# Altium schematic sheet MCU.SchDoc
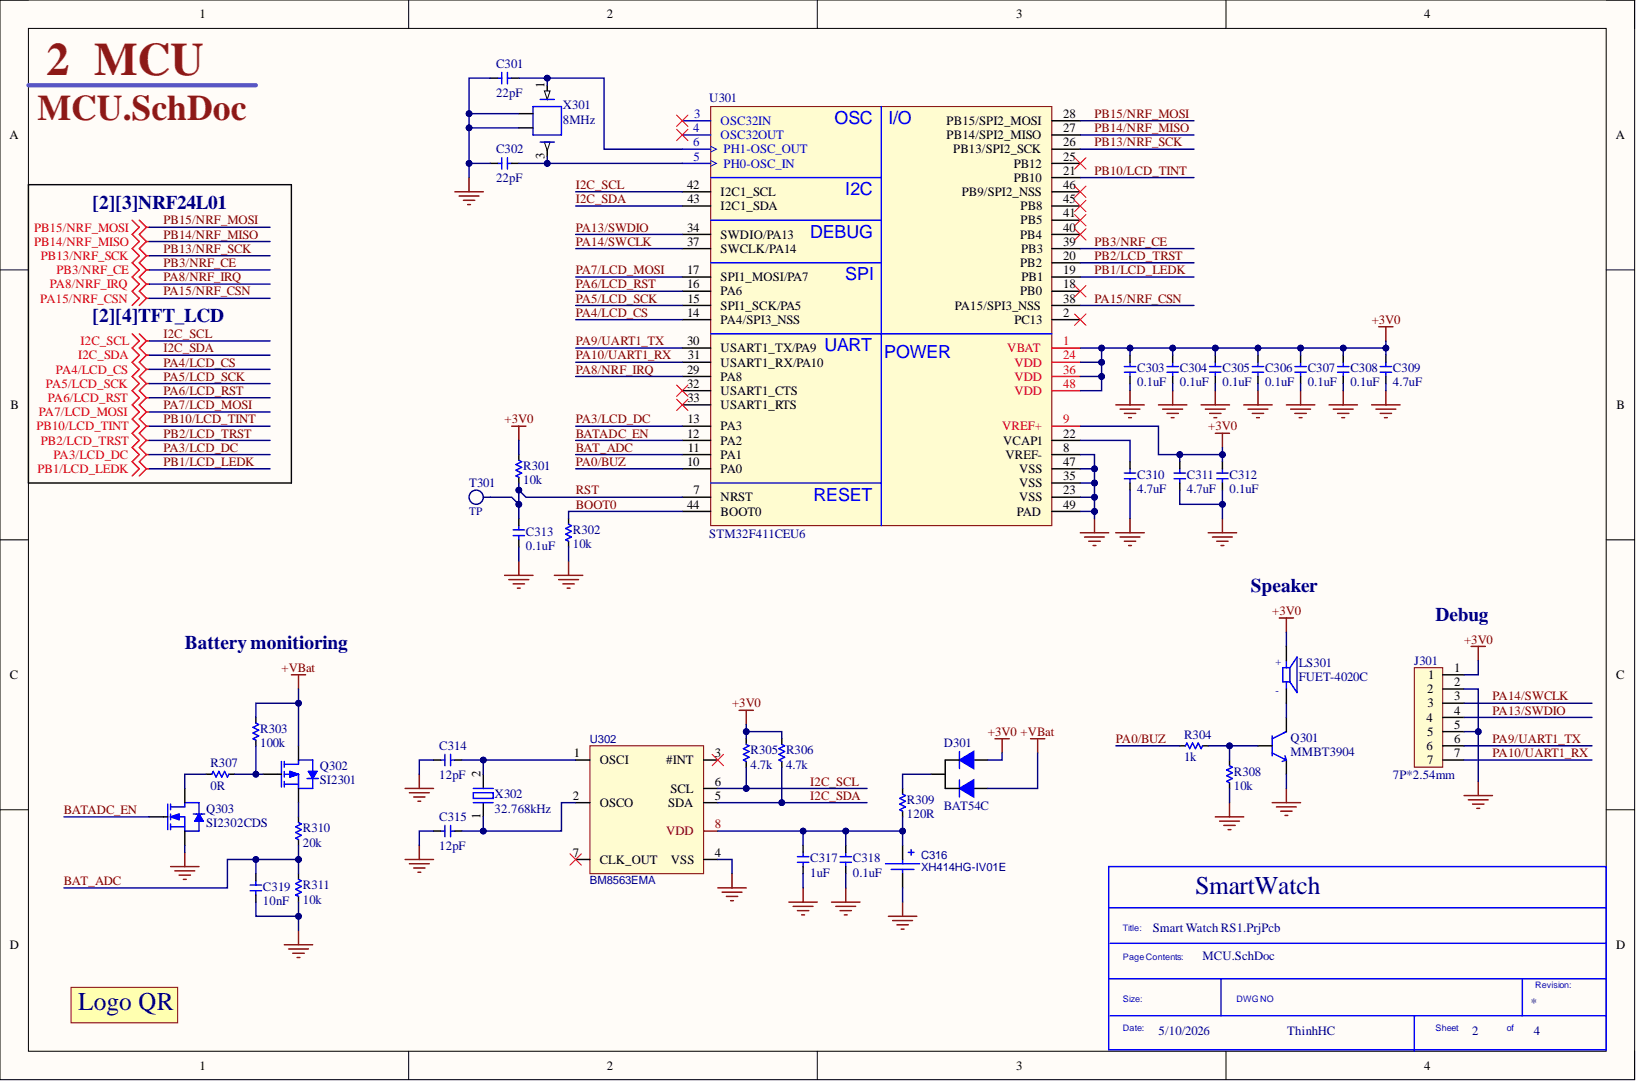
[diagram p0: MCU.SchDoc]

## Parts
C301  22pF  [C_0402]
C302  22pF  [C_0402]
C303  0.1uF  [C_0402]
C304  0.1uF  [C_0402]
C305  0.1uF  [C_0402]
C306  0.1uF  [C_0402]
C307  0.1uF  [C_0402]
C308  0.1uF  [C_0402]
C309  4.7uF  [C_0402]
C310  4.7uF  [C_0402]
C311  4.7uF  [C_0402]
C312  0.1uF  [C_0402]
C313  0.1uF  [C_0402]
C314  12pF  [C_0402]
C315  12pF  [C_0402]
C316  XH414HG-IV01E  [CAP-TH_XH414HG]  (no BOM)
C317  1uF  [C_0402]
C318  0.1uF  [C_0402]
C319  10nF  [C_0402]
D301  BAT54C  [SOT-23-3_L2.9-W1.6-P1.90-LS2.8-BR]
J301  7P*2.54mm  [7P*2.54mm]  (no BOM)
LO301  LOGO QR  [LOGO QR]  (value not drawn)  (no BOM)
LS301  FUET-4020C  [Buzzer SMD]
Q301  MMBT3904  [SOT-23-3]
Q302  SI2301  [SOT-23-3_L2.9-W1.3-P1.90-LS2.4-BR]
Q303  SI2302CDS  [SOT-23-3_L2.9-W1.3-P1.90-LS2.4-BR]
R301  10k  [R_0402]
R302  10k  [R_0402]
R303  100k  [R_0402]
R304  1k  [R_0402]
R305  4.7k  [R_0402]
R306  4.7k  [R_0402]
R307  0R  [R_0402]
R308  10k  [R_0402]
R309  120R  [R_0402]
R310  20k  [R_0402]
R311  10k  [R_0402]
T301  TP  [TP-D45MIL]  (no BOM)
U301  STM32F411CEU6  [UFQFPN-48_L7.0-W7.0-P0.50-BL-EP]
U302  BM8563EMA  [MSOP-8_L3.0-W3.0-P0.65-LS5.0-BL]
X301  8MHz  [CRYSTAL_SMD3225_4P]
X302  32.768kHz  [CRYSTAL-SMD_L3.2-W1.5]

## Nets
+3V0: C303.2 C304.2 C305.2 C306.2 C307.2 C308.2 C309.2 C311.2 C312.2 D301.1 J301.1 LS301.1 R301.2 R305.2 R306.2 U301.1(VBAT) U301.9(VREF+) U301.24(VDD) U301.36(VDD) U301.48(VDD)
+VBat: D301.2 Q302.3 R303.2
GND: C301.1 C302.1 C303.1 C304.1 C305.1 C306.1 C307.1 C308.1 C309.1 C310.1 C311.1 C312.1 C313.1 C314.1 C315.1 C316.2 C317.1 C318.1 C319.1 J301.2 J301.5 Q301.2 Q303.2 R302.1 R308.1 R311.1 U301.8(VREF-) U301.23(VSS) U301.35(VSS) U301.47(VSS) U301.49(PAD) U302.4(VSS) X301.2 X301.4
BAT_ADC: C319.2 R310.1 R311.2 U301.11(PA1)
BATADC_EN: Q303.1 U301.12(PA2)
BOOT0: R302.2 U301.44(BOOT0)
I2C_SCL: R305.1 U301.42(I2C1_SCL) U302.6(SCL)
I2C_SDA: R306.1 U301.43(I2C1_SDA) U302.5(SDA)
PA0/BUZ: R304.1 U301.10(PA0)
PA3/LCD_DC: U301.13(PA3)
PA4/LCD_CS: U301.14(PA4/SPI3_NSS)
PA5/LCD_SCK: U301.15(SPI1_SCK/PA5)
PA6/LCD_RST: U301.16(PA6)
PA7/LCD_MOSI: U301.17(SPI1_MOSI/PA7)
PA8/NRF_IRQ: U301.29(PA8)
PA9/UART1_TX: J301.6 U301.30(USART1_TX/PA9)
PA10/UART1_RX: J301.7 U301.31(USART1_RX/PA10)
PA13/SWDIO: J301.4 U301.34(SWDIO/PA13)
PA14/SWCLK: J301.3 U301.37(SWCLK/PA14)
PA15/NRF_CSN: U301.38(PA15/SPI3_NSS)
PB1/LCD_LEDK: U301.19(PB1)
PB2/LCD_TRST: U301.20(PB2)
PB3/NRF_CE: U301.39(PB3)
PB10/LCD_TINT: U301.21(PB10)
PB13/NRF_SCK: U301.26(PB13/SPI2_SCK)
PB14/NRF_MISO: U301.27(PB14/SPI2_MISO)
PB15/NRF_MOSI: U301.28(PB15/SPI2_MOSI)
RST: C313.2 R301.1 T301.1 U301.7(NRST)
NetC301_2: C301.2 U301.6(PH1-OSC_OUT) X301.1
NetC302_2: C302.2 U301.5(PH0-OSC_IN) X301.3
NetC310_2: C310.2 U301.22(VCAP1)
NetC314_2: C314.2 U302.1(OSCI) X302.2
NetC315_2: C315.2 U302.2(OSCO) X302.1
NetC316_1: C316.1 C317.2 C318.2 R309.1 U302.8(VDD)
NetD301_3: D301.3 R309.2
NetLS301_2: LS301.2 Q301.3
NetQ301_1: Q301.1 R304.2 R308.2
NetQ302_1: Q302.1 R303.1 R307.2
NetQ302_2: Q302.2 R310.2
NetQ303_3: Q303.3 R307.1

Not connected: U301 2(PC13) 3(OSC32IN) 4(OSC32OUT) 18(PB0) 25(PB12) 32(USART1_CTS) 33(USART1_RTS) 40(PB4) 41(PB5) 45(PB8) 46(PB9/SPI2_NSS); U302 3(#INT) 7(CLK_OUT)

## Library data of the parts
C301 C302 = 0402CG220J500NT: 22pF 0402 50V C0G ±5% Multilayer Ceramic Capacitors MLCC - SMD/SMT ROHS; Manufacturer: FH (Guangdong Fenghua Advanced Tech); Assembly Type: SMT Assembly; Component Kind: Standard; ComponentLink1Description: Digikey Link; ComponentLink1URL: https://www.digikey.com/en/products/detail/yageo/CC0603KRX7R9BB104/2103082?s=N4IgTCBcDaIMwEYEFoFwCzuWZA5AIiALoC%2BQA; ComponentLink2Description: LCSC Link; ComponentLink2URL: https://jlcpcb.com/parts/componentSearch?searchTxt=10k%200603; LCSC Part Number: C1555; Library Name: Smart Watch RS1.SchLib; Manufacturer Part Number: 0402CG220J500NT; Package: 0402
C303-C308 C312 C313 C318 = CL05B104KO5NNNC: 100nF 0402 16V X7R ±10% Multilayer Ceramic Capacitors MLCC - SMD/SMT ROHS; Manufacturer: Samsung Electro-Mechanics; Assembly Type: SMT Assembly; Component Kind: Standard; ComponentLink1Description: Digikey Link; ComponentLink1URL: https://www.digikey.com/en/products/detail/yageo/CC0603KRX7R9BB104/2103082?s=N4IgTCBcDaIMwEYEFoFwCzuWZA5AIiALoC%2BQA; ComponentLink2Description: LCSC Link; ComponentLink2URL: https://jlcpcb.com/parts/componentSearch?searchTxt=10k%200603; LCSC Part Number: C1525; Manufacturer Part Number: CL05B104KO5NNNC; Package: 0402; Library Name: HomeMade SmartWatch.SchLib
C309-C311 = CL05A475MP5NRNC: 4.7uF 0402 10V X5R ±20% Multilayer Ceramic Capacitors MLCC - SMD/SMT ROHS; Manufacturer: Samsung Electro-Mechanics; Assembly Type: SMT Assembly; Component Kind: Standard; ComponentLink1Description: Digikey Link; ComponentLink1URL: https://www.digikey.com/en/products/detail/yageo/CC0603KRX7R9BB104/2103082?s=N4IgTCBcDaIMwEYEFoFwCzuWZA5AIiALoC%2BQA; ComponentLink2Description: LCSC Link; ComponentLink2URL: https://jlcpcb.com/parts/componentSearch?searchTxt=10k%200603; LCSC Part Number: C23733; Library Name: Smart Watch RS1.SchLib; Manufacturer Part Number: CL05A475MP5NRNC; Package: 0402
C314 C315 = 0402CG120J500NT: 12pF 0402 50V C0G ±5% Multilayer Ceramic Capacitors MLCC - SMD/SMT ROHS; Manufacturer: FH (Guangdong Fenghua Advanced Tech); Assembly Type: SMT Assembly; Component Kind: Standard; ComponentLink1Description: Digikey Link; ComponentLink1URL: https://www.digikey.com/en/products/detail/yageo/CC0603KRX7R9BB104/2103082?s=N4IgTCBcDaIMwEYEFoFwCzuWZA5AIiALoC%2BQA; ComponentLink2Description: LCSC Link; ComponentLink2URL: https://jlcpcb.com/parts/componentSearch?searchTxt=10k%200603; LCSC Part Number: C1547; Manufacturer Part Number: 0402CG120J500NT; Package: 0402; Library Name: HomeMade SmartWatch.SchLib
C316 = XH414HG-IV01E: Cap Supercap 80000uF 3.3V (4.8 X 4.8 X 1.85mm) SMD Stacked Coin; Assembly Type: SMT Assembly; LCSC Part Number: C9900013866; Library Name: Smart Watch RS1.SchLib; Manufacturer: N/A; Manufacturer Part Number: XH414HG-IV01E; Package: SMD
C317 = CL05A105KA5NQNC: 1uF 0402 16V X7R ±10% Multilayer Ceramic Capacitors MLCC - SMD/SMT ROHS; Manufacturer: Samsung Electro-Mechanics; Assembly Type: SMT Assembly; Component Kind: Standard; ComponentLink1Description: Digikey Link; ComponentLink1URL: https://www.digikey.com/en/products/detail/yageo/CC0603KRX7R9BB104/2103082?s=N4IgTCBcDaIMwEYEFoFwCzuWZA5AIiALoC%2BQA; ComponentLink2Description: LCSC Link; ComponentLink2URL: https://jlcpcb.com/parts/componentSearch?searchTxt=10k%200603; LCSC Part Number: C52923; Manufacturer Part Number: CL05A105KA5NQNC; Package: 0402; Library Name: HomeMade SmartWatch.SchLib
C319 = CL05B103KB5NNNC: 10nF 0402 50V X7R ±10% Multilayer Ceramic Capacitors MLCC - SMD/SMT ROHS; Manufacturer: Samsung Electro-Mechanics; Assembly Type: SMT Assembly; Component Kind: Standard; ComponentLink1Description: Digikey Link; ComponentLink1URL: https://www.digikey.com/en/products/detail/yageo/CC0603KRX7R9BB104/2103082?s=N4IgTCBcDaIMwEYEFoFwCzuWZA5AIiALoC%2BQA; ComponentLink2Description: LCSC Link; ComponentLink2URL: https://jlcpcb.com/parts/componentSearch?searchTxt=10k%200603; LCSC Part Number: C15195; Library Name: Smart Watch RS1.SchLib; Manufacturer Part Number: CL05B103KB5NNNC; Package: 0402
D301 = BAT54CLT1G: SCHOTTKY BARRIER DIODES (SBD) SOT-23(SOT-23-3) ROHS; Manufacturer: ON Semicon; Assembly Type: SMT Assembly; Component Kind: Standard; LCSC Part Number: C14308; Manufacturer Part Number: BAT54CLT1G; Package: SOT-23-3; Library Name: HomeMade SmartWatch.SchLib
LS301 = FUET-4020C: -30℃~+70℃ 100mA 2V~4V 2mm 3V 4kHz 4mm 4mm SMD SMD,4x4mm Buzzers ROHS; Manufacturer: FUET; Assembly Type: SMT Assembly; Component Kind: Standard; LCSC Part Number: C41410568; Library Name: Smart Watch RS1.SchLib; Manufacturer Part Number: FUET-4020C; Package: SMD,4x4mm
Q301 = MMBT3904: MMBT3904 TRANSISTORS (NPN/PNP) NPN 200MA 40V SOT-23(SOT-23-3) ROHS; Manufacturer: Changjiang Electronics Tech (CJ); Assembly Type: SMT Assembly; Component Kind: Standard; LCSC Part Number: C20526; Library Name: Smart Watch RS1.SchLib; Manufacturer Part Number: MMBT3904; Package: SOT-23-3
Q302 = SI2301CDS: 1 P-Channel 1.6W -55℃~+150℃ 10nC@4.5V 142mΩ@2.5V 1V 20V 3.1A 405pF 55pF 75pF P-Channel SOT-23 MOSFETs ROHS; Manufacturer: Vishay Intertech; Manufacturer Part Number: SI2301CDS-T1-GE3; Assembly Type: SMT Assembly; Component Kind: Standard; ComponentLink1Description: Digikey Link; ComponentLink2Description: LCSC Link; ComponentLink2URL: https://jlcpcb.com/parts/componentSearch?searchTxt=10k%200603; LCSC Part Number: C10487; Library Name: Smart Watch RS1.SchLib; Package: SOT-23
Q303 = SI2302CDS: 1 N-channel 2.9A 20V 3.5nC@10V,4.5V 550mW 75mΩ@2.5V 850mV N-Channel SOT-23 MOSFETs ROHS; Manufacturer: Vishay Intertech; Manufacturer Part Number: Si2302CDS-T1-GE3; Assembly Type: SMT Assembly; Component Kind: Standard; ComponentLink1Description: Digikey Link; ComponentLink2Description: LCSC Link; ComponentLink2URL: https://jlcpcb.com/parts/componentSearch?searchTxt=10k%200603; LCSC Part Number: C10488; Library Name: Smart Watch RS1.SchLib; Package: SOT-23
R301 R302 R308 R311 = 0402WGF1002TCE: 10kΩ 0402 50V 62.5mW Thick Film Resistors ±1%  Chip Resistor - Surface Mount ROHS; Manufacturer: UNI-ROYAL(Uniroyal Elec); Assembly Type: SMT Assembly; Component Kind: Standard; LCSC Part Number: C25744; Library Name: Smart Watch RS1.SchLib; Manufacturer Part Number: 0402WGF1002TCE; Package: 0402
R303 = 0402WGF1003TCE: 100kΩ 0402 50V -55℃~+155℃ 62.5mW Thick Film Resistor ±1% ±100ppm/℃ Chip Resistor - Surface Mount ROHS; Manufacturer: UNI-ROYAL(Uniroyal Elec); Assembly Type: SMT Assembly; Component Kind: Standard; LCSC Part Number: C25741; Library Name: Smart Watch RS1.SchLib; Manufacturer Part Number: 0402WGF1003TCE; Package: 0402
R304 = 0402WGF1001TCE: 1kΩ 0402 50V 62.5mW Thick Film Resistors ±1%  Chip Resistor - Surface Mount ROHS; Manufacturer: UNI-ROYAL(Uniroyal Elec); Assembly Type: SMT Assembly; Component Kind: Standard; LCSC Part Number: C11702; Library Name: Smart Watch RS1.SchLib; Manufacturer Part Number: 0402WGF1001TCE; Package: 0402
R305 R306 = 0402WGF4701TCE: 4.7kΩ 0402 50V -55℃~+155℃ 62.5mW Thick Film Resistor ±1% ±100ppm/℃ Chip Resistor - Surface Mount ROHS; Manufacturer: UNI-ROYAL(Uniroyal Elec); Assembly Type: SMT Assembly; Component Kind: Standard; LCSC Part Number: C25900; Library Name: Smart Watch RS1.SchLib; Manufacturer Part Number: 0402WGF4701TCE; Package: 0402
R307 = 0402WGF0000TCE: 0Ω 0402 50V -55℃~+155℃ 62.5mW Thick Film Resistor ±1% ±800ppm/℃ Chip Resistor - Surface Mount ROHS; Manufacturer: UNI-ROYAL(Uniroyal Elec); Assembly Type: SMT Assembly; Component Kind: Standard; LCSC Part Number: C17168; Library Name: Smart Watch RS1.SchLib; Manufacturer Part Number: 0402WGF0000TCE; Package: 0402
R309 = 0402WGF1200TCE: 120Ω 0402 50V -55℃~+155℃ 62.5mW Thick Film Resistor ±1% ±100ppm/℃ Chip Resistor - Surface Mount ROHS; Manufacturer: UNI-ROYAL(Uniroyal Elec); Assembly Type: SMT Assembly; Component Kind: Standard; LCSC Part Number: C25079; Manufacturer Part Number: 0402WGF1200TCE; Package: 0402; Library Name: HomeMade SmartWatch.SchLib
R310 = 0402WGF2002TCE: 20kΩ 0402 50V 62.5mW Thick Film Resistors ±1%  Chip Resistor - Surface Mount ROHS; Manufacturer: UNI-ROYAL(Uniroyal Elec); Assembly Type: SMT Assembly; Component Kind: Standard; LCSC Part Number: C25765; Library Name: Smart Watch RS1.SchLib; Manufacturer Part Number: 0402WGF2002TCE; Package: 0402
T301 = TEST-POINT'4545': Manufacturer: No; Description: TEST-POINT'4545'; LCSC Part Number: No; Library Name: Smart Watch RS1.SchLib; Manufacturer Part Number: No; Package: No
U301 = STM32F411CEU6: -40℃~+85℃ 1.7V~3.6V 100MHz 128KB 12bit 32 Bit 36 512KB ARM Cortex-M4 Built-in FLASH UFQFPN-48(7x7) Microcontrollers (MCU/MPU/SOC) ROHS; Manufacturer: STMicroelectronics; Assembly Type: SMT Assembly; Component Kind: Standard; LCSC Part Number: C60420; Library Name: Smart Watch RS1.SchLib; Manufacturer Part Number: STM32F411CEU6; Package: UFQFPN-48(7x7)
U302 = BM8563EMA: MSOP-8  Real-time Clocks (RTC) ROHS; Manufacturer: GATEMODE(捷茂微); Assembly Type: SMT Assembly; Component Kind: Standard; LCSC Part Number: C269878; Manufacturer Part Number: BM8563EMA; Package: MSOP-8; Library Name: HomeMade SmartWatch.SchLib
X301 = X32258MSB4SI: 8MHz 20pF 120Ω -40℃~+85℃ Crystal Oscillator ±10ppm ±20ppm SMD3225-4P Crystals ROHS; Manufacturer: YXC Crystal Oscillators; Assembly Type: SMT Assembly; Component Kind: Standard; LCSC Part Number: C2682774; Library Name: Smart Watch RS1.SchLib; Manufacturer Part Number: X32258MSB4SI; Package: SMD3225-4P
X302 = Q13FC13500005: 32.768kHz 12.5pF ±10ppm SMD3215-2P Crystals ROHS; Manufacturer: Seiko Epson; Assembly Type: SMT Assembly; Component Kind: Standard; ComponentLink1Description: Digikey Link; ComponentLink1URL: https://www.digikey.com/en/products/detail/yageo/CC0603KRX7R9BB104/2103082?s=N4IgTCBcDaIMwEYEFoFwCzuWZA5AIiALoC%2BQA; ComponentLink2Description: LCSC Link; ComponentLink2URL: https://jlcpcb.com/parts/componentSearch?searchTxt=10k%200603; LCSC Part Number: C841881; Library Name: Smart Watch RS1.SchLib; Manufacturer Part Number: Q13FC13500005; Package: SMD3215-2P
J301 = 7P*2.54mm: Assembly Type: Handing Solder; ComponentLink1Description: Digikey Link; ComponentLink1URL: https://www.digikey.com/en/products/detail/yageo/CC0603KRX7R9BB104/2103082?s=N4IgTCBcDaIMwEYEFoFwCzuWZA5AIiALoC%2BQA; ComponentLink2Description: LCSC Link; ComponentLink2URL: https://jlcpcb.com/parts/componentSearch?searchTxt=10k%200603; Description: 7P*2.54mm; LCSC Part Number: -; Library Name: Smart Watch RS1.SchLib; Manufacturer: -; Manufacturer Part Number: 7P*2.54mm; Package: -
LO301 = LOGO QR: Description: LOGO QR; Library Name: Smart Watch RS1.SchLib
